# Altium schematic sheet YS_JTAG2SWD.SchDoc(YS_JTAG2SWD)
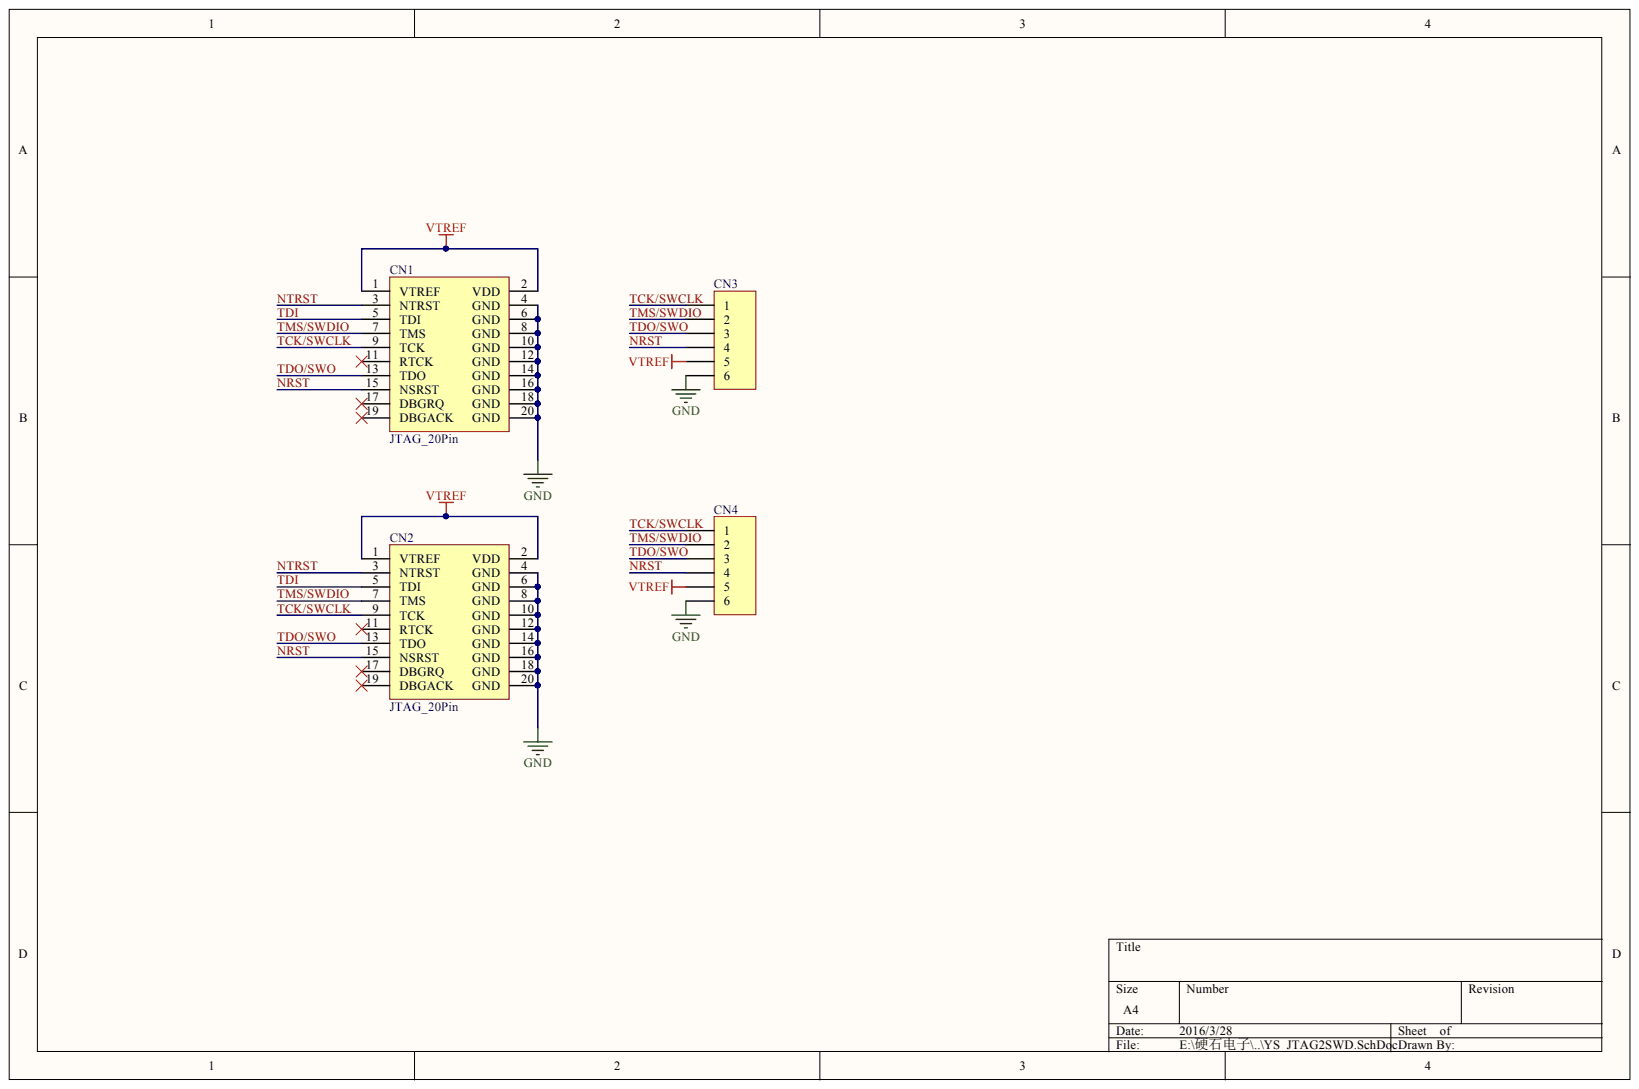
[diagram p0: YS_JTAG2SWD.SchDoc]

## Parts
CN1  JTAG_20Pin  [DC3-20P _90_2]
CN2  JTAG_20Pin  [DC3-20P]
CN3  Header 6  [XH2.54-6P_90]  (value not drawn)
CN4  Header 6  [CON1X6(2.54mm)]  (value not drawn)

## Nets
GND: CN1.4(GND) CN1.6(GND) CN1.8(GND) CN1.10(GND) CN1.12(GND) CN1.14(GND) CN1.16(GND) CN1.18(GND) CN1.20(GND) CN2.4(GND) CN2.6(GND) CN2.8(GND) CN2.10(GND) CN2.12(GND) CN2.14(GND) CN2.16(GND) CN2.18(GND) CN2.20(GND) CN3.6 CN4.6
VTREF: CN1.1(VTREF) CN1.2(VDD) CN2.1(VTREF) CN2.2(VDD) CN3.5 CN4.5
NRST: CN1.15(NSRST) CN2.15(NSRST) CN3.4 CN4.4
NTRST: CN1.3(NTRST) CN2.3(NTRST)
TCK/SWCLK: CN1.9(TCK) CN2.9(TCK) CN3.1 CN4.1
TDI: CN1.5(TDI) CN2.5(TDI)
TDO/SWO: CN1.13(TDO) CN2.13(TDO) CN3.3 CN4.3
TMS/SWDIO: CN1.7(TMS) CN2.7(TMS) CN3.2 CN4.2

Not connected: CN1 11(RTCK) 17(DBGRQ) 19(DBGACK); CN2 11(RTCK) 17(DBGRQ) 19(DBGACK)

## Library data of the parts
CN3 CN4 = Header 6: Header, 6-Pin; Component Kind: Standard; LatestRevisionDate: 17-Jul-2002; LatestRevisionNote: Re-released for DXP Platform.; Signal Integrity: Connector; Library Name: YS_SCHLIB.SchLib; Publisher: Altium Limited
CN1 CN2 = JTAG_20Pin: Component Kind: Standard; Library Name: YS_SCHLIB.SchLib
